# Altium schematic sheet 17_FLOW_Sensor.SchDoc(17_FLOW_Sensor)
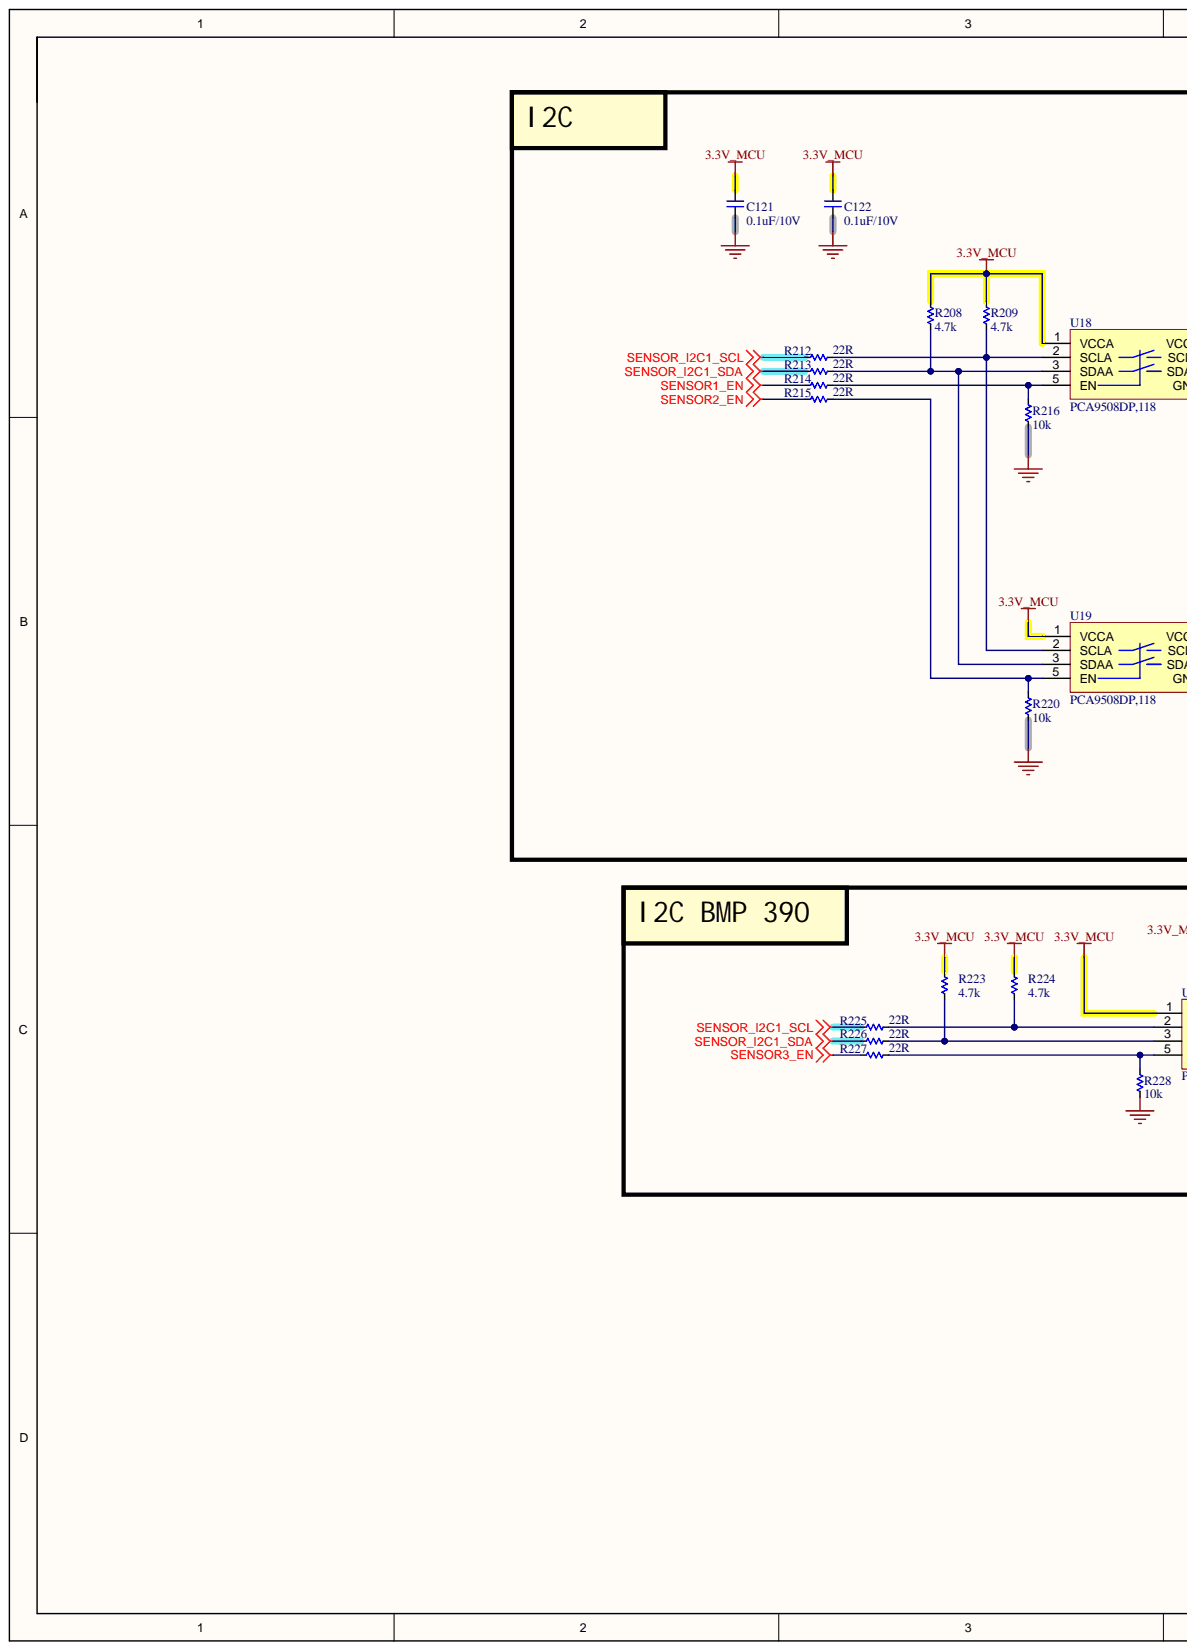
[diagram p0: 17_FLOW_Sensor.SchDoc, left]
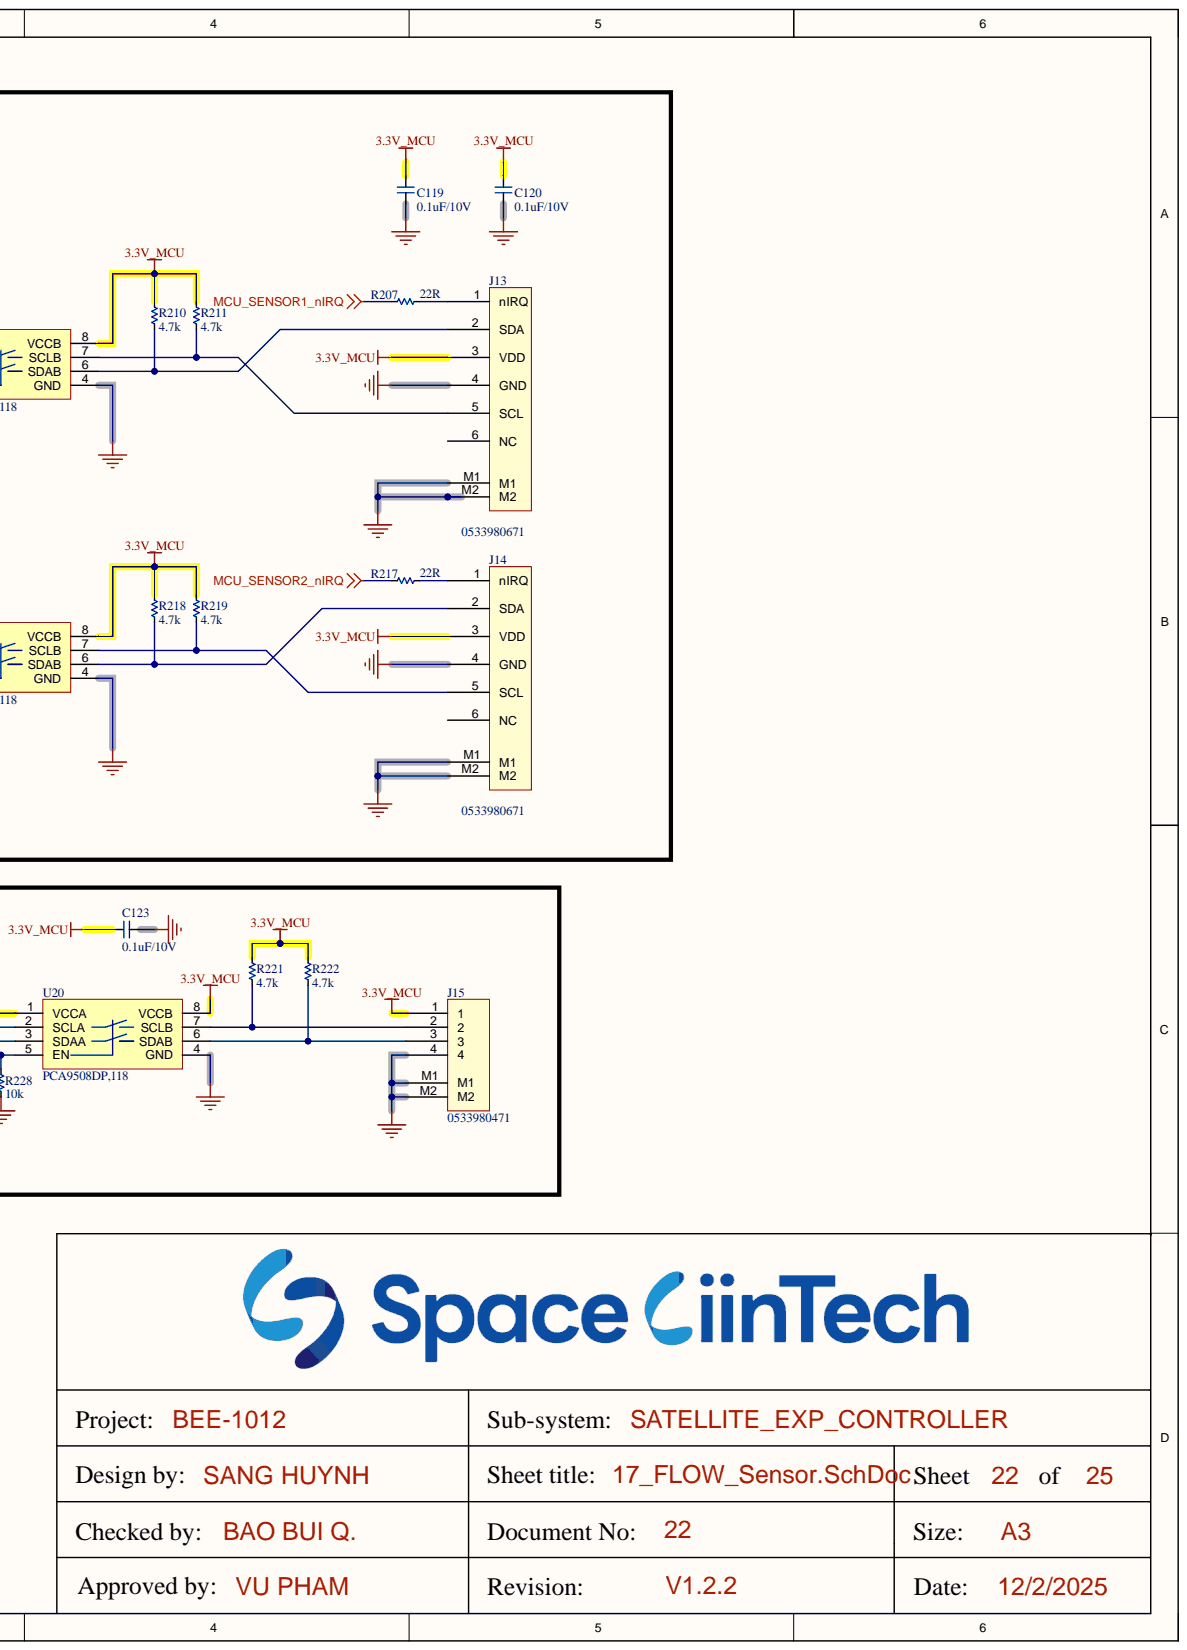
[diagram p1: 17_FLOW_Sensor.SchDoc, right]

## Parts
C119  0.1uF/10V  [CAP_C_1005X60]
C120  0.1uF/10V  [CAP_C_1005X60]
C121  0.1uF/10V  [CAP_C_1005X60]
C122  0.1uF/10V  [CAP_C_1005X60]
C123  0.1uF/10V  [CAP_C_1005X60]
J13  0533980671  [MOLEX_0533980671]
J14  0533980671  [MOLEX_0533980671]
J15  0533980471  [MOLEX_0533980471]
R207  22R  [RES_C_1005X40]
R208  4.7k  [RES_C_1005X40]
R209  4.7k  [RES_C_1005X40]
R210  4.7k  [RES_C_1005X40]
R211  4.7k  [RES_C_1005X40]
R212  22R  [RES_C_1005X40]
R213  22R  [RES_C_1005X40]
R214  22R  [RES_C_1005X40]
R215  22R  [RES_C_1005X40]
R216  10k  [RES_C_1005X40]
R217  22R  [RES_C_1005X40]
R218  4.7k  [RES_C_1005X40]
R219  4.7k  [RES_C_1005X40]
R220  10k  [RES_C_1005X40]
R221  4.7k  [RES_C_1005X40]
R222  4.7k  [RES_C_1005X40]
R223  4.7k  [RES_C_1005X40]
R224  4.7k  [RES_C_1005X40]
R225  22R  [RES_C_1005X40]
R226  22R  [RES_C_1005X40]
R227  22R  [RES_C_1005X40]
R228  10k  [RES_C_1005X40]
U18  PCA9508DP,118  [TSOP8]
U19  PCA9508DP,118  [TSOP8]
U20  PCA9508DP,118  [TSOP8]

## Nets
3.3V_MCU: C119.2 C120.2 C121.2 C122.2 C123.2 J13.3(VDD) J14.3(VDD) J15.1 R208.1 R209.1 R210.1 R211.1 R218.1 R219.1 R221.1 R222.1 R223.1 R224.1 U18.1(VCCA) U18.8(VCCB) U19.1(VCCA) U19.8(VCCB) U20.1(VCCA) U20.8(VCCB)
GND: C119.1 C120.1 C121.1 C122.1 C123.1 J13.4(GND) J13.M1 J13.M2 J14.4(GND) J14.M1 J14.M2 J15.4 J15.M1 J15.M2 R216.2 R220.2 R228.2 U18.4(GND) U19.4(GND) U20.4(GND)
MCU_SENSOR1_nIRQ: R207.2
MCU_SENSOR2_nIRQ: R217.2
SENSOR1_EN: R214.2
SENSOR2_EN: R215.2
SENSOR3_EN: R227.2
SENSOR_I2C1_SCL: R212.2 R225.2
SENSOR_I2C1_SDA: R213.2 R226.2
NetJ13_1: J13.1(nIRQ) R207.1
NetJ13_2: J13.2(SDA) R210.2 U18.6(SDAB)
NetJ13_5: J13.5(SCL) R211.2 U18.7(SCLB)
NetJ14_1: J14.1(nIRQ) R217.1
NetJ14_2: J14.2(SDA) R218.2 U19.6(SDAB)
NetJ14_5: J14.5(SCL) R219.2 U19.7(SCLB)
NetJ15_2: J15.2 R221.2 U20.7(SCLB)
NetJ15_3: J15.3 R222.2 U20.6(SDAB)
NetR208_2: R208.2 R213.1 U18.3(SDAA) U19.3(SDAA)
NetR209_2: R209.2 R212.1 U18.2(SCLA) U19.2(SCLA)
NetR214_1: R214.1 R216.1 U18.5(EN)
NetR215_1: R215.1 R220.1 U19.5(EN)
NetR223_2: R223.2 R226.1 U20.3(SDAA)
NetR224_2: R224.2 R225.1 U20.2(SCLA)
NetR227_1: R227.1 R228.1 U20.5(EN)

Not connected: J13 6(NC); J14 6(NC)

## Library data of the parts
C119-C123 = _CAP_C_2: CAP CHIP; Component Kind: Standard; Library Name: LABLIB.SchLib
J13 J14 = FLOW_SENSOR: Component Kind: Standard; Library Name: new_component.SchLib
J15 = Header 4x1_2M: Component Kind: Standard; Library Name: LABLIB.SchLib
R207-R228 = _RES_B_2: RES; Component Kind: Standard; Library Name: LABLIB.SchLib
U18-U20 = PCA9508DP,118: Component Kind: Standard; Library Name: EXP_HW_V3.SchLib
